# Altium schematic sheet Power.SchDoc(Power)
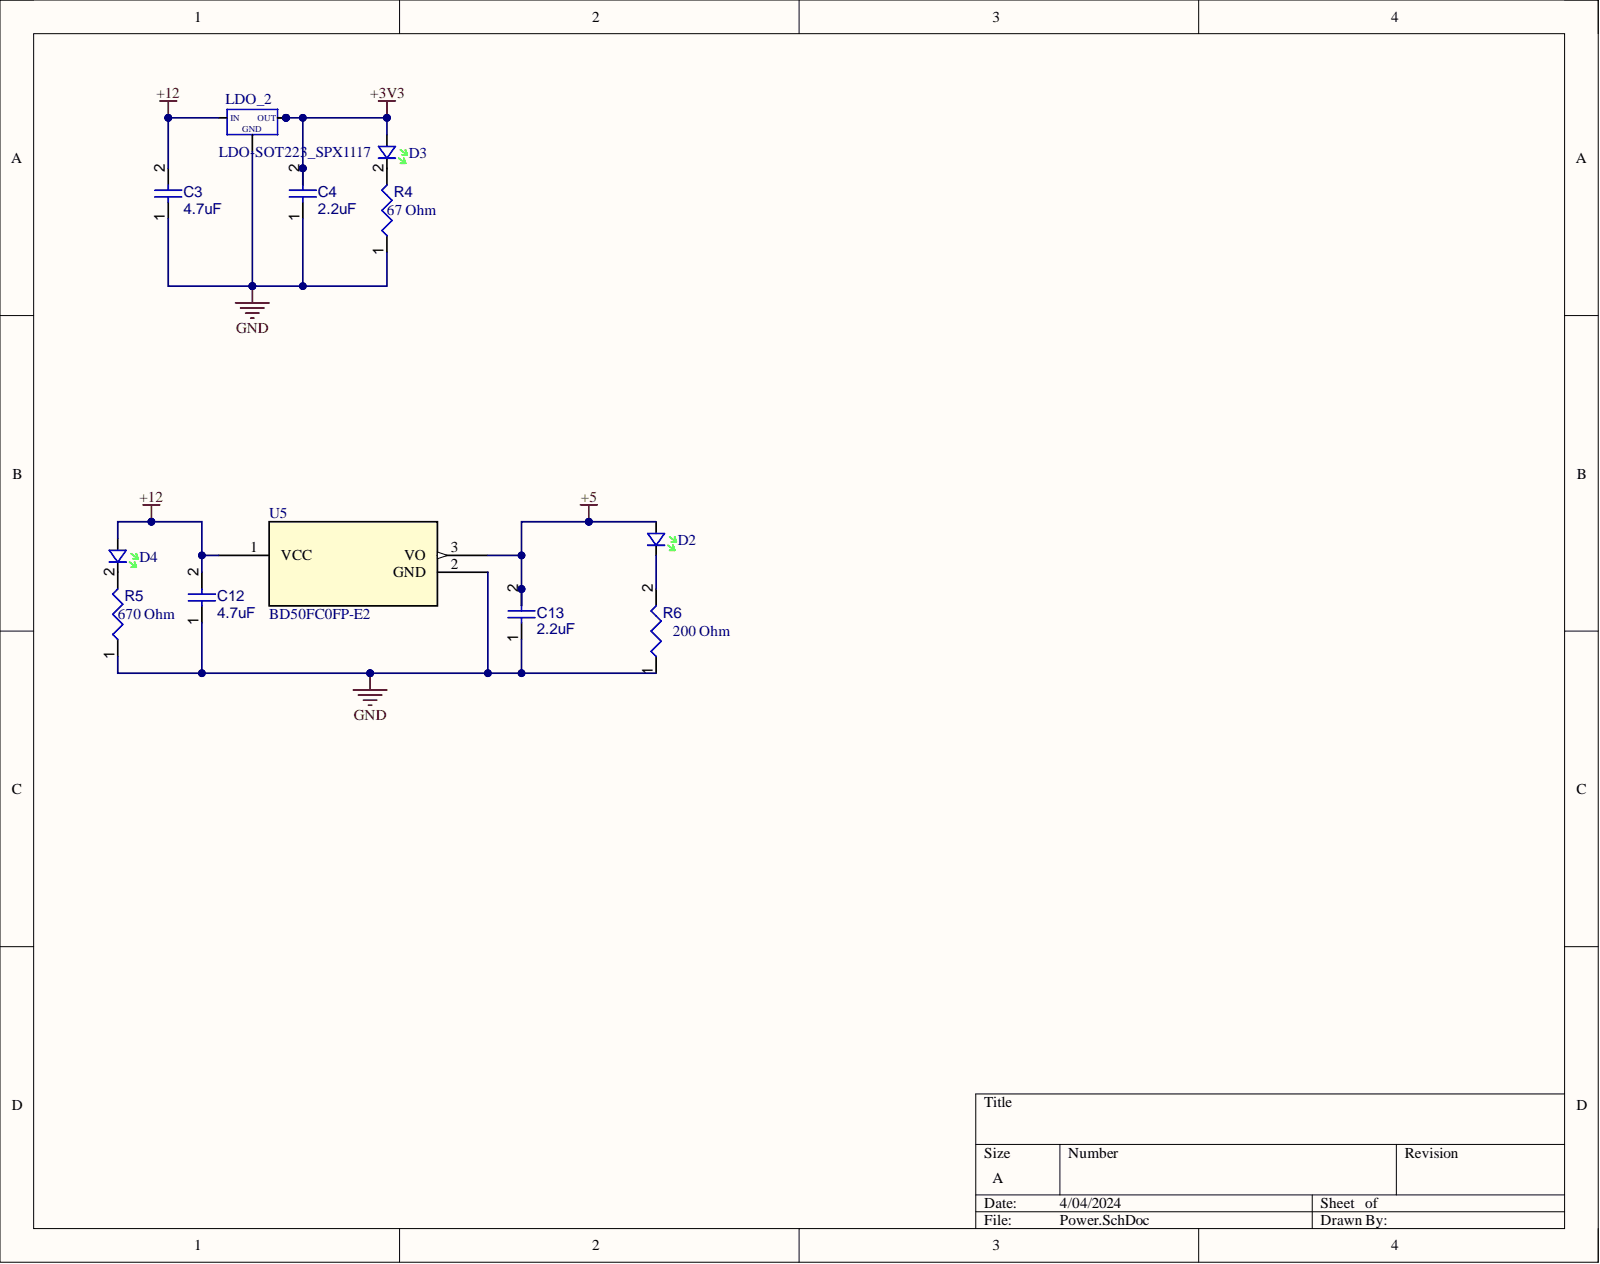
[diagram p0: Power.SchDoc]

## Parts
C3  4.7uF
C4  2.2uF
C12  4.7uF
C13  2.2uF
D2  CMP-009-000195-1  (value not drawn)
D3  CMP-009-000195-1  (value not drawn)
D4  CMP-009-000195-1  (value not drawn)
LDO_2  LDO-SOT223_SPX1117
R4  value 67 Ohm
R5  value 670 Ohm
R6  value 200 Ohm
U5  BD50FC0FP-E2

## Nets
+3V3: C4.2 D3.A LDO_2.2(OUT) LDO_2.4
+5: C13.2 D2.A U5.3
+12: C3.2 C12.2 D4.A LDO_2.3(IN) U5.1
GND: C3.1 C4.1 C12.1 C13.1 LDO_2.1 R4.1 R5.1 R6.1 U5.2
NetD2_K: D2.K R6.2
NetD3_K: D3.K R4.2
NetD4_K: D4.K R5.2

## Library data of the parts
C3 C12 = CMP-005-000636-1: Chip Capacitor, 4.7µF +/-20%, 10V, 0603, Thickness 1 mm; Available Preview Images: 2D; Component Kind: Standard; Design Item ID: CMP-001-00076-2; Source: Integrated_Library - 12.03.21 - 15.39.SchLib; Library Name: Illini Formula Electric
C4 C13 = CMP-005-000616-1: Chip Capacitor, 2,2µF +/-20%, 10V, 0402, Thickness 0.6 mm; Available Preview Images: 2D; Component Kind: Standard; Design Item ID: CMP-001-00056-2; Source: Integrated_Library - 12.03.21 - 15.39.SchLib; Library Name: Illini Formula Electric
LDO_2 = CMP-009-000212-1: Component Kind: Standard; Design Item ID: LDO-SOT223_SPX1117; Library Name: Illini Formula Electric; Source: ife19_Elec_Library.SchLib
R4-R6 = CMP-009-000068-1: 120R 0.1W 5% 0603 (1608 Metric)  SMD; Available Preview Images: 2D; Case-EIA: 0603; Case-Metric: 1608; Component Kind: Standard; Design Item ID: CMP-009-00069-1; Source: Integrated_Library - 12.03.21 - 15.39.SchLib; Technology: SMT; Library Name: Illini Formula Electric
U5 = CMP-141-000000-1: No Description Available; Mfr_Name: ROHM Semiconductor; Component Kind: Standard; Design Item ID: BD50FC0FP-E2; Manufacturer_Part_Number: BD50FC0FP-E2; RefDes: RefDes; Source: BD50FC0FP-E2.SchLib; Type: DEV; Copyright: Copyright (C) 2024 Ultra Librarian. All rights reserved.; Library Name: Illini Formula Electric
D2-D4 = CMP-009-000195-1: Component Kind: Standard; Design Item ID: LEDGREEN-0603; Library Name: Illini Formula Electric; Source: ife19_Elec_Library.SchLib
